# Altium schematic sheet Power.SchDoc(Power)
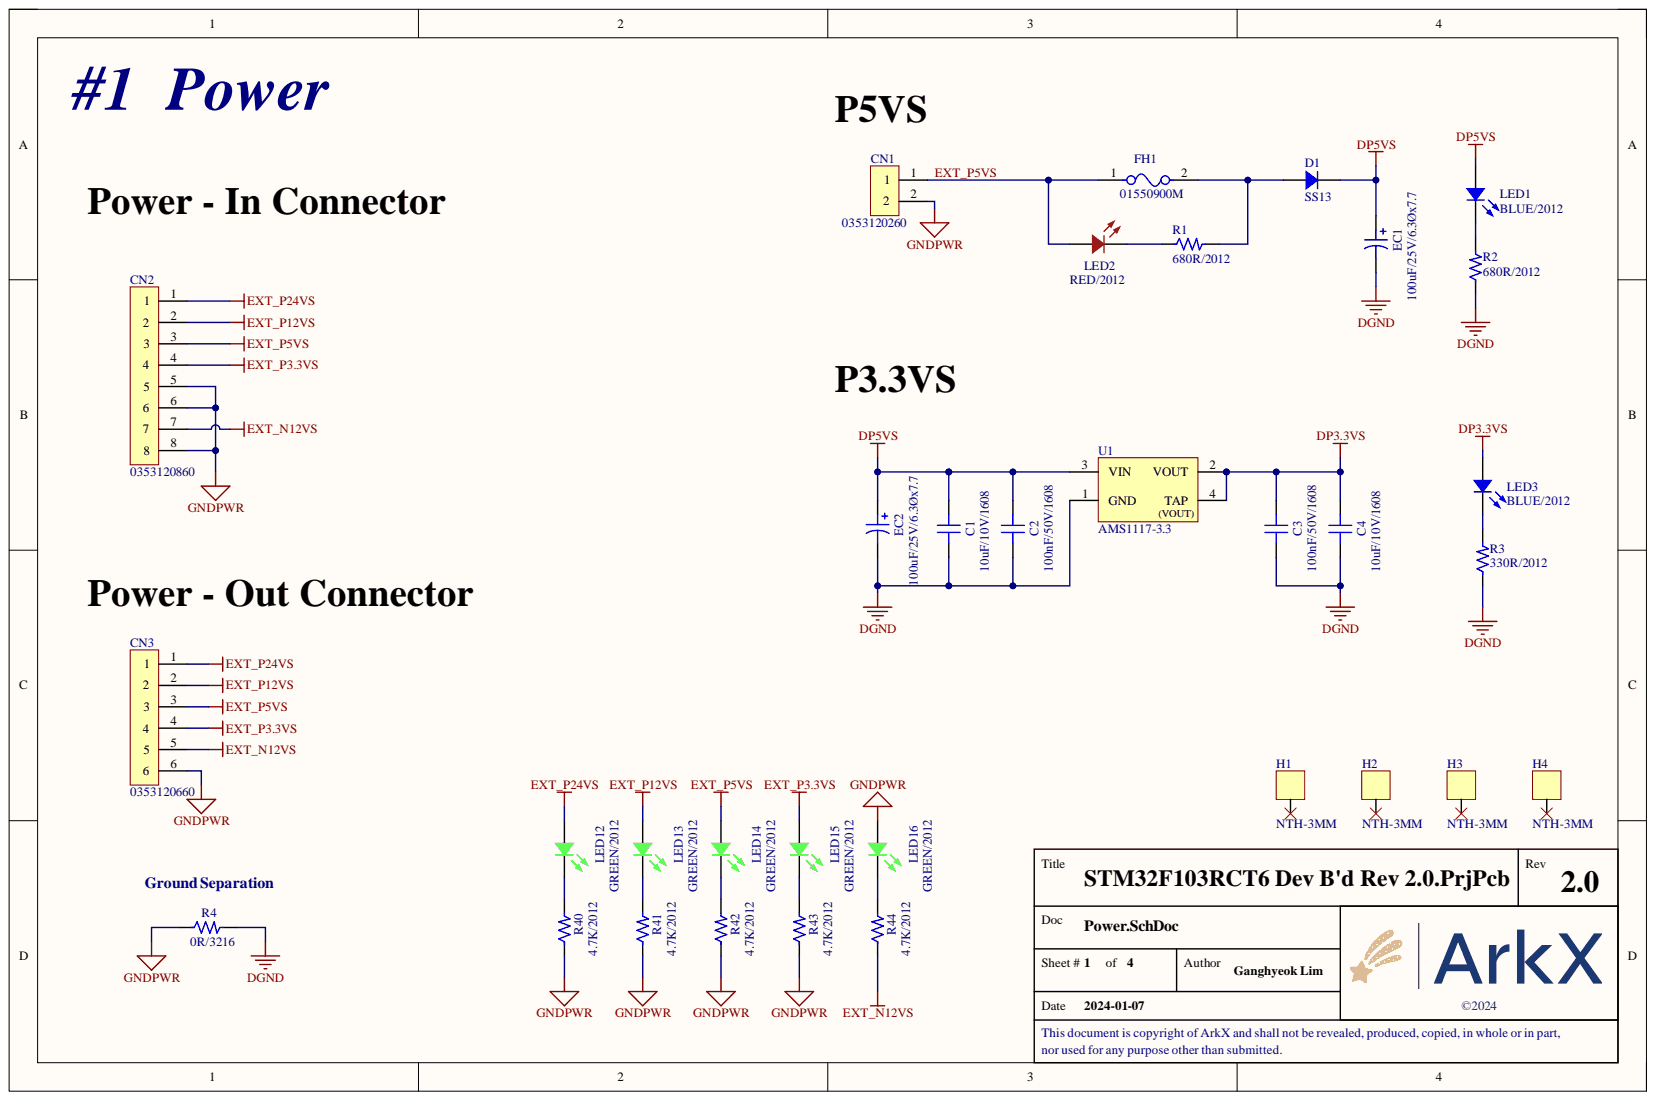
[diagram p0: Power.SchDoc]

## Parts
C1  10uF/10V/1608  [CAP 1608 (0603_INCH)]
C2  100nF/50V/1608  [CAP 1608 (0603_INCH)]
C3  100nF/50V/1608  [CAP 1608 (0603_INCH)]
C4  10uF/10V/1608  [CAP 1608 (0603_INCH)]
CN1  0353120260  [CON 0353120260 (MOLEX)]
CN2  0353120860  [CON 0353120860 (MOLEX)]
CN3  0353120660  [CON 0353120660 (MOLEX)]
D1  SS13  [DIO DO-214AC (SMA)]
EC1  100uF/25V/6.3Øx7.7  [CAP ALSMD 6.3MM H8.0MM]
EC2  100uF/25V/6.3Øx7.7  [CAP ALSMD 6.3MM H8.0MM]
FH1  01550900M  [FUSE 01550900M_SMD]
H1  NTH-3MM  [HOLE NTH-3MM]
H2  NTH-3MM  [HOLE NTH-3MM]
H3  NTH-3MM  [HOLE NTH-3MM]
H4  NTH-3MM  [HOLE NTH-3MM]
LED1  BLUE/2012  [LED MHT170RGBCT (BLUE)]
LED2  RED/2012  [LED MHT170RGBCT (RED)]
LED3  BLUE/2012  [LED MHT170RGBCT (BLUE)]
LED12  GREEN/2012  [LED MHT170RGBCT (GREEN)]
LED13  GREEN/2012  [LED MHT170RGBCT (GREEN)]
LED14  GREEN/2012  [LED MHT170RGBCT (GREEN)]
LED15  GREEN/2012  [LED MHT170RGBCT (GREEN)]
LED16  GREEN/2012  [LED MHT170RGBCT (GREEN)]
R1  680R/2012  [RES 2012 (0805_inch)]
R2  680R/2012  [RES 2012 (0805_inch)]
R3  330R/2012  [RES 2012 (0805_inch)]
R4  0R/3216  [RES 3216 (1206_inch)]
R40  4.7K/2012  [RES 2012 (0805_inch)]
R41  4.7K/2012  [RES 2012 (0805_inch)]
R42  4.7K/2012  [RES 2012 (0805_inch)]
R43  4.7K/2012  [RES 2012 (0805_inch)]
R44  4.7K/2012  [RES 2012 (0805_inch)]
U1  AMS1117-3.3  [SOT 223-3 (DIODES INCORPORATED)]

## Nets
DGND: C1.1 C2.1 C3.1 C4.1 EC1.2 EC2.2 R2.1 R3.1 R4.2 U1.1(GND)
DP3.3VS: C3.2 C4.2 LED3.4 U1.2(VOUT) U1.4(TAP)
DP5VS: C1.2 C2.2 D1.2 EC1.1 EC2.1 LED1.4 U1.3(VIN)
EXT_N12VS: CN2.7 CN3.5 R44.1
EXT_P3.3VS: CN2.4 CN3.4 LED15.4
EXT_P12VS: CN2.2 CN3.2 LED13.4
EXT_P24VS: CN2.1 CN3.1 LED12.4
GNDPWR: CN1.2 CN2.5 CN2.6 CN2.8 CN3.6 LED16.4 R4.1 R40.1 R41.1 R42.1 R43.1
EXT_P5VS: CN1.1 CN2.3 CN3.3 FH1.1 LED2.4 LED14.4
NetD1_1: D1.1 FH1.2 R1.2
NetLED1_3: LED1.3 R2.2
NetLED2_1: LED2.1 R1.1
NetLED3_3: LED3.3 R3.2
NetLED12_2: LED12.2 R40.2
NetLED13_2: LED13.2 R41.2
NetLED14_2: LED14.2 R42.2
NetLED15_2: LED15.2 R43.2
NetLED16_2: LED16.2 R44.2

Not connected: H1 1; H2 1; H3 1; H4 1

## Library data of the parts
C1 C4 = CAP_10uF/10V/1608: Ceramic CAP_10uF/10V/1608; Component Kind: Standard; JLCPCB Part Type: Basic Part; LCSC Part #: C19702; Library Name: Arkx.IntLib
C2 C3 = CAP_100nF/50V/1608: Ceramic CAP_100nF/50V/1608; Component Kind: Standard; JLCPCB Part Type: Basic Part; LCSC Part #: C14663; Library Name: Arkx.IntLib
CN1 = CON_0353120260: CONN HEADER VERT 2POS 2.5MM; Component Kind: Standard; Library Name: Arkx.IntLib
CN2 = CON_0353120860: CONN HEADER VERT 8POS 2.5MM; Component Kind: Standard; Library Name: Arkx.IntLib
CN3 = CON_0353120660: CONN HEADER VERT 6POS 2.5MM; Component Kind: Standard; Library Name: Arkx.IntLib
D1 = DIO_SS13: DIODE SCHOTTKY 30V 1A SMA; Component Kind: Standard; JLCPCB Part Type: Extended Part; LCSC Part #: C64984; Library Name: Arkx.IntLib
EC1 EC2 = CAP_EC 100uF/25V/6.3Øx7.7: Eletrolytic CAP_100uF/25V/6.3Øx7.7; Component Kind: Standard; JLCPCB Part Type: Extended Part; LCSC Part #: C127971; Library Name: Arkx.IntLib
FH1 = FUSE_01550900M-SMD: Fuse Block 10A 125V 1 Circuit Cartridge Surface Mount; Component Kind: Standard; JLCPCB Part Type: Extended Part; LCSC Part #: C108518; Library Name: Arkx.IntLib
H1-H4 = HOLE_NTH-3MM: Non-Through Hole 3mm; Component Kind: Standard; Library Name: Arkx.IntLib
LED1 LED3 = LED_MHT170RGBCT(BLUE): Colorless transparence -30℃~+85℃ Positive post Common anode RGB 120° 0805  Light Emitting Diodes (LED) ROHS; Component Kind: Standard; JLCPCB Part Type: Extended Part; LCSC Part #: C2962094; Library Name: Arkx.IntLib
LED2 = LED_MHT170RGBCT(RED): Colorless transparence -30℃~+85℃ Positive post Common anode RGB 120° 0805  Light Emitting Diodes (LED) ROHS; Component Kind: Standard; JLCPCB Part Type: Extended Part; LCSC Part #: C2962094; Library Name: Arkx.IntLib
LED12-LED16 = LED_MHT170RGBCT(GREEN): Colorless transparence -30℃~+85℃ Positive post Common anode RGB 120° 0805  Light Emitting Diodes (LED) ROHS; Component Kind: Standard; JLCPCB Part Type: Extended Part; LCSC Part #: C2962094; Library Name: Arkx.IntLib
R1 R2 = RES_680R/2012/0.125W: Power Rating: 0.125W; Precision: 1%; Value: 680R; Component Kind: Standard; Description: RES_680R/2012/0.125W; JLCPCB Part Type: Basic Part; LCSC Part #: C17798; Size: 2012; Library Name: Arkx.IntLib
R3 = RES_330R/2012/0.125W: Power Rating: 0.125W; Precision: 1%; Value: 330R; Component Kind: Standard; Description: RES_330R/2012/0.125W; JLCPCB Part Type: Basic Part; LCSC Part #: C17630; Size: 2012; Library Name: Arkx.IntLib
R4 = RES_0R/3216/0.25W: Power Rating: 0.1W; Precision: 1%; Component Kind: Standard; Description: RES_0R/3216/0.25W; JLCPCB Part Type: Basic Part; LCSC Part #: C17888; Size: 1608; Library Name: Arkx.IntLib
R40-R44 = RES_4.7K/2012/0.125W: Power Rating: 0.125W; Precision: 1%; Value: 4.7K; Component Kind: Standard; Description: RES_4.7K/2012/0.125W; JLCPCB Part Type: Basic Part; LCSC Part #: C17673; Size: 2012; Library Name: Arkx.IntLib
U1 = IC_REG_AMS1117-3.3: 72dB@(120Hz) 1A 1.3V@(800mA) Fixed 3.3V~3.3V Positive 1 SOT-223  Linear Voltage Regulators (LDO) ROHS; Component Kind: Standard; JLCPCB Part Type: Basic Part; LCSC Part #: C6186; Library Name: Arkx.IntLib
